# Altium schematic sheet GSM.SchDoc
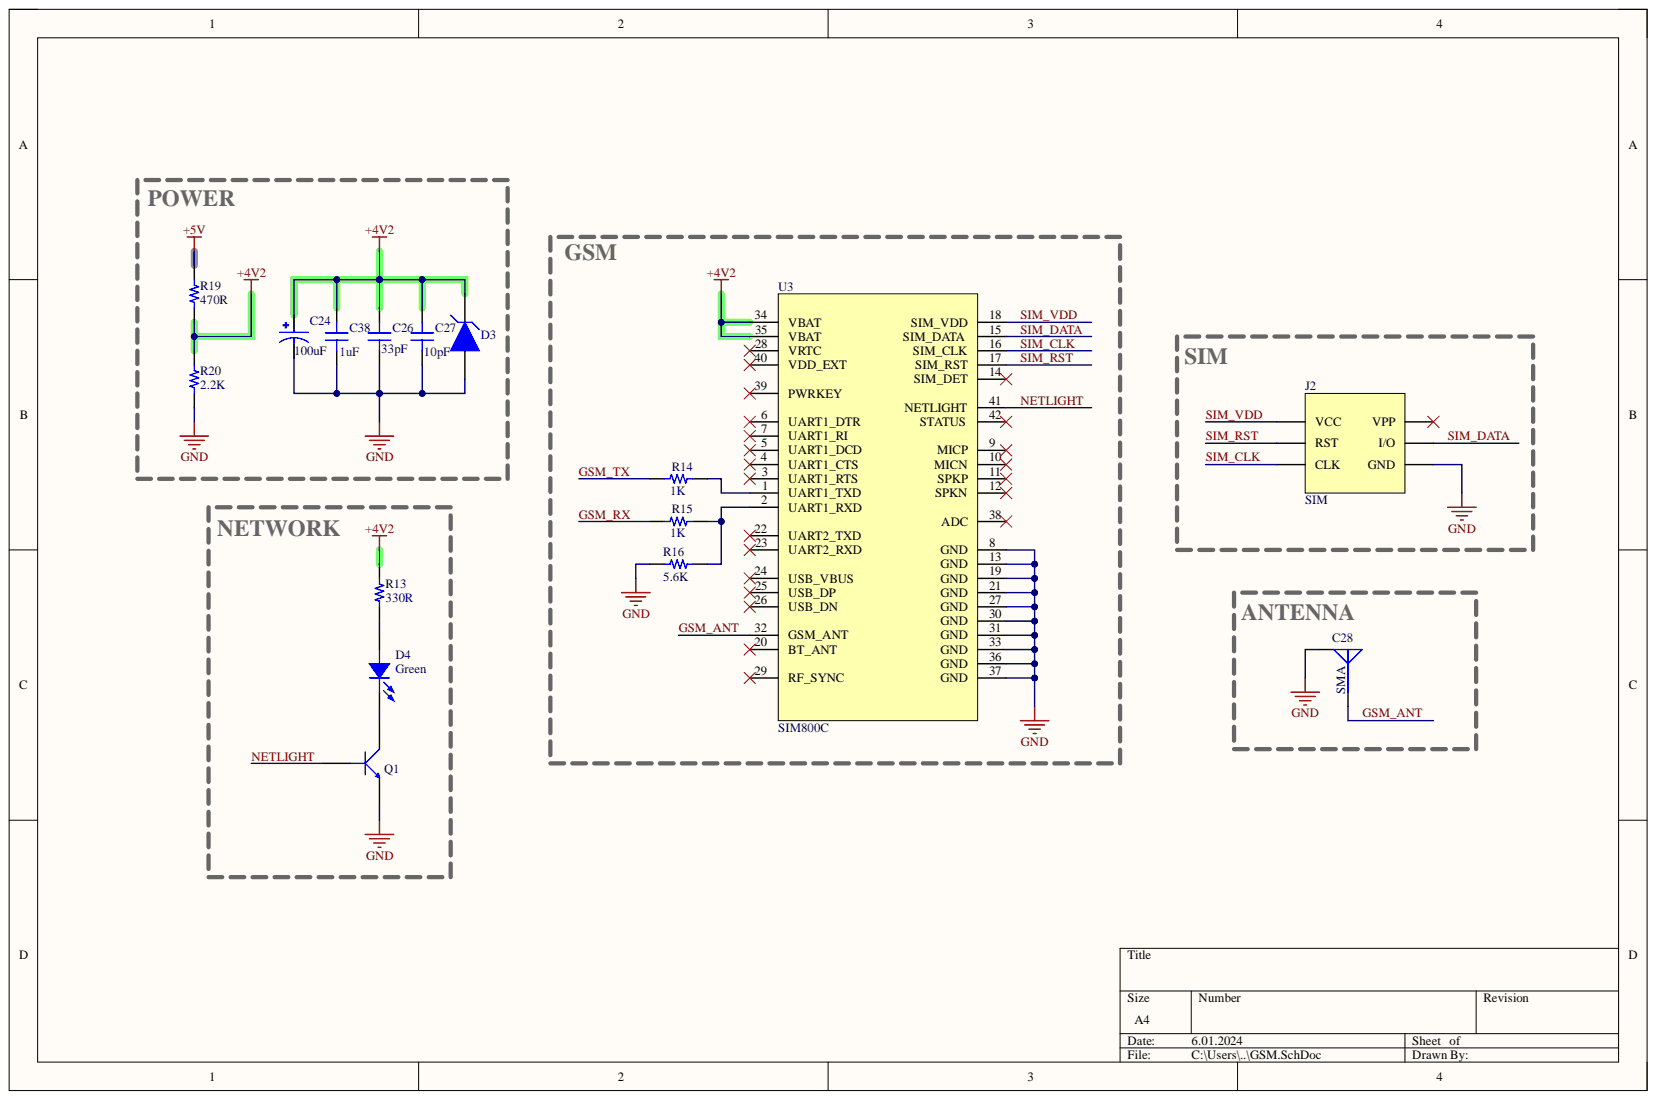
[diagram p0: GSM.SchDoc]

## Parts
C24  100uF  [TANTAL B-CASE]
C26  33pF  [CAP 0603_1608]
C27  10pF  [CAP 0603_1608]
C28  SMA  [132134-11 SMA ANTEN]
C38  1uF  [CAP 0603_1608]
D3  ZENER DIODE  [SOD123]  (value not drawn)
D4  value Green  [0805 Green LED]  (hidden comment: 0805 Green LED)
J2  SIM  [GCT_SIM7100-6-1-15-00-A_REVC]
Q1  MMBT3904-7-F  [SOT-23F]  (value not drawn)
R13  330R  [RES 0603_1608]
R14  1K  [RES 0603_1608]
R15  1K  [RES 0603_1608]
R16  5.6K  [RES 0603_1608]
R19  470R  [RES 0603_1608]
R20  2.2K  [RES 0603_1608]
U3  SIM800C  [LCC110P1570X1760X250-42N]

## Nets
+4V2: C24.+ C26.2 C27.2 C38.2 D3.C R13.2 R19.1 R20.2 U3.34(VBAT) U3.35(VBAT)
+5V: R19.2
GND: C24.- C26.1 C27.1 C28.G C38.1 D3.A J2.C5(GND) Q1.2 R16.1 R20.1 U3.8(GND) U3.13(GND) U3.19(GND) U3.21(GND) U3.27(GND) U3.30(GND) U3.31(GND) U3.33(GND) U3.36(GND) U3.37(GND)
GSM_ANT: C28.S U3.32(GSM_ANT)
GSM_RX: R15.1
GSM_TX: R14.1
NETLIGHT: Q1.1 U3.41(NETLIGHT)
SIM_CLK: J2.C3(CLK) U3.16(SIM_CLK)
SIM_DATA: J2.C7(I/O) U3.15(SIM_DATA)
SIM_RST: J2.C2(RST) U3.17(SIM_RST)
SIM_VDD: J2.C1(VCC) U3.18(SIM_VDD)
NetD4_1: D4.1 R13.1
NetD4_2: D4.2 Q1.3
NetR14_2: R14.2 U3.1(UART1_TXD)
NetR15_2: R15.2 R16.2 U3.2(UART1_RXD)

Not connected: J2 C6(VPP); U3 3(UART1_RTS) 4(UART1_CTS) 5(UART1_DCD) 6(UART1_DTR) 7(UART1_RI) 9(MICP) 10(MICN) 11(SPKP) 12(SPKN) 14(SIM_DET) 20(BT_ANT) 22(UART2_TXD) 23(UART2_RXD) 24(USB_VBUS) 25(USB_DP) 26(USB_DN) 28(VRTC) 29(RF_SYNC) 38(ADC) 39(PWRKEY) 40(VDD_EXT) 42(STATUS)

## Library data of the parts
C24 = 100uF: TANTAL; Component Kind: Standard; Library Name: hava_kalitesi_olcumu.SchLib
C26 = 33pF: CERAMİC 1206 SMD; Component Kind: Standard; Library Name: hava_kalitesi_olcumu.SchLib
C27 = 10pF: CERAMİC 1206 SMD; Component Kind: Standard; Library Name: hava_kalitesi_olcumu.SchLib
C28 = Anten SMA  2: SMA Anten Connector; Component Kind: Standard; ComponentLink1Description: Özdisan; ComponentLink1URL: https://www.ozdisan.com/konnektor-ve-baglanti-elemanlari/koaksiyel-konnektorler/sma-konnektorler/CONSMA002-G; Library Name: hava_kalitesi_olcumu.SchLib
C38 = 1uF: CERAMİC 1206 SMD; Component Kind: Standard; Library Name: hava_kalitesi_olcumu.SchLib
D3 = ZENER DIODE: SOD123; Manufacturer: ON Semiconductor; Manufacturer Part Number: 1SMB5919BT3G; Breakdown Voltage: 5.88V; Element Configuration: Single; ESD Protection: Yes; Forward Voltage: 1.5V; Material: Plastic; Max Power Dissipation: 3W; Max Reverse Current: 5uA; Power Dissipation: 550mW; Test Current: 66.9mA; Tolerance: 5%; Voltage Rating (DC): 5.6V; Zener Current: 267mA; Impedance: 2R; Case/Package: SMB; Category: Zener Diodes; Component Kind: Standard; ComponentLink2Description: https://datasheet.ciiva.com/1182/1smb5913bt3-d-1182657.pdf?src-supplier=Element14; ComponentLink2URL: https://datasheet.ciiva.com/1182/1smb5913bt3-d-1182657.pdf?src-supplier=Element14; ComponentLink3Description: https://datasheet.ciiva.com/1182/1smb5913bt3-d-1182658.pdf?src-supplier=Element14; ComponentLink3URL: https://datasheet.ciiva.com/1182/1smb5913bt3-d-1182658.pdf?src-supplier=Element14; ComponentLink4Description: https://datasheet.ciiva.com/895/1smb5913bt3-d-895203.pdf?src-supplier=Digi-Key; ComponentLink4URL: https://datasheet.ciiva.com/895/1smb5913bt3-d-895203.pdf?src-supplier=Digi-Key; ComponentLink5Description: https://datasheet.ciiva.com/895/1smb5913bt3-d-895204.pdf?src-supplier=Digi-Key; ComponentLink5URL: https://datasheet.ciiva.com/895/1smb5913bt3-d-895204.pdf?src-supplier=Digi-Key; ComponentLink6Description: https://datasheet.ciiva.com/895/1smb5913bt3-d-895205.pdf?src-supplier=Digi-Key; ComponentLink6URL: https://datasheet.ciiva.com/895/1smb5913bt3-d-895205.pdf?src-supplier=Digi-Key; ComponentLink7Description: https://datasheet.ciiva.com/15198/getdatasheetpartid-311040-15198695.pdf?src-supplier=Verical; ComponentLink7URL: https://datasheet.ciiva.com/15198/getdatasheetpartid-311040-15198695.pdf?src-supplier=Verical; ComponentLink8Description: https://datasheet.ciiva.com/16311/1smb5913bt3-d-16311847.pdf?src-supplier=Future+Electronics; ComponentLink8URL: https://datasheet.ciiva.com/16311/1smb5913bt3-d-16311847.pdf?src-supplier=Future+Electronics; ComponentLink9Description: https://datasheet.ciiva.com/16472/1smb5913bt3-d-16472779.pdf?src-supplier=Avnet+Asia; ComponentLink9URL: https://datasheet.ciiva.com/16472/1smb5913bt3-d-16472779.pdf?src-supplier=Avnet+Asia; Contact Plating: Tin,Matte; Halogen Free: Halogen Free; Height: 2.13mm; Lead Free: Lead Free; Length: 4.32mm; Max Operating Temperature: 150°C; Max Reverse Leakage Current: 5uA; Min Operating Temperature: -65°C; Number of Pins: 2; Package Quantity: 1; Packaging: Tape and Reel; Power Rating: 3W; Pricing 1: 1=0,45000, 10=0,31900, 100=0,16110, 500=0,14274, 1000=0,11109 (USD); Radiation Hardening: No; REACH SVHC: No SVHC; RoHS: Yes; Supplier 1: Digi-Key; Supplier Part Number 1: 1SMB5919BT3GOSCT-ND; Voltage Tolerance: 5%; Width: 3.56mm; Zener Voltage: 5.6V; Library Name: hava_kalitesi_olcumu.SchLib
D4 = 0805 Green LED: Manufacturer: AVAGO Technologies; Manufacturer Code: HSMG-C170; Current: 20mA; Voltage: 2.2V; Component Kind: Standard; Farnell Code: 5790852; Unit Price: 0,134 €; Library Name: hava_kalitesi_olcumu.SchLib
J2 = SIM7100-6-1-15-00-A: Memory Card Connectors Micro SIM Card Connector - Push-Push Type, 6 Pin, SMT, 1.50mm Profile; MF: Global Connector Technology; Availability: Unavailable; Component Kind: Standard; ComponentLink1Description: Özdisan; ComponentLink1URL: https://ozdisan.com/konnektor-ve-baglanti-elemanlari/hafiza-konnektorleri/pc-kart-soketleri/L-KLS1-SIM-064-62P-H1; MP: SIM7100-6-1-15-00-A; Package: None; Price: None; SnapEDA_Link: https://www.snapeda.com/parts/SIM7100-6-1-15-00-A/Global%20Connector%20Technology/view-part/449163/?ref=snap; Library Name: hava_kalitesi_olcumu.SchLib
Q1 = MMBT3904-7-F: Transistor General Purpose BJT NPN 40 Volt 0.2A Automotive 3-Pin SOT-23; Manufacturer: Diodes Zetex; Collector Base Voltage (VCBO): 60V; Collector Emitter Breakdown Voltage: 40V; Collector Emitter Saturation Voltage: 300mV; Continuous Collector Current: 200mA; Designed by: BERK; Element Configuration: Single; Emitter Base Voltage (VEBO): 6V; Frequency: 300MHz; hFE Min: 100; Max Power Dissipation: 300mW; Number of Elements: 1; Power Dissipation: 350mW; Case/Package: SOT-23; Category: BJTs; Collector Emitter Voltage (VCEO): 40V; Collector-emitter Voltage-Max: 300mV; Component Kind: Standard; ComponentLink1Description: https://datasheet.ciiva.com/11201/ds30036-11201778.pdf?src-supplier=Avnet; ComponentLink1URL: https://datasheet.ciiva.com/11201/ds30036-11201778.pdf?src-supplier=Avnet; ComponentLink2Description: https://datasheet.ciiva.com/15138/getdatasheetpartid-53265-15138780.pdf?src-supplier=Verical; ComponentLink2URL: https://datasheet.ciiva.com/15138/getdatasheetpartid-53265-15138780.pdf?src-supplier=Verical; ComponentLink3Description: https://datasheet.ciiva.com/6361/ds30036-6361254.pdf?src-supplier=Digi-Key; ComponentLink3URL: https://datasheet.ciiva.com/6361/ds30036-6361254.pdf?src-supplier=Digi-Key; ComponentLink4Description: https://datasheet.ciiva.com/22711/1915516-22711750.pdf?src-supplier=Element14; ComponentLink4URL: https://datasheet.ciiva.com/22711/1915516-22711750.pdf?src-supplier=Element14; ComponentLink5Description: https://datasheet.ciiva.com/11198/ds30036-11198999.pdf?src-supplier=Avnet; ComponentLink5URL: https://datasheet.ciiva.com/11198/ds30036-11198999.pdf?src-supplier=Avnet; ComponentLink6Description: https://datasheet.ciiva.com/6361/ds30036-6361256.pdf?src-supplier=Digi-Key; ComponentLink6URL: https://datasheet.ciiva.com/6361/ds30036-6361256.pdf?src-supplier=Digi-Key; Contact Plating: Tin,Matte; Gain Bandwidth Product: 300MHz; Height: 1mm; Lead Free: Lead Free; Length: 3.05mm; Manufacturer Part Number: MMBT3904-7-F; Max Breakdown Voltage: 40V; Max Collector Current: 200mA; Max Frequency: 300MHz; Max Operating Temperature: 150°C; Min Operating Temperature: -55°C; Mount: Surface Mount; Number of Pins: 3; Package Quantity: 3000; Packaging: Tape and Reel; Polarity: NPN; Pricing 1: 1=0,12000, 10=0,08600, 25=0,08280, 100=0,04660, 500=0,03664, 1000=0,02544 (USD); Radiation Hardening: No; REACH SVHC: No SVHC; RoHS: Yes; RoHS Compliant: Yes; Series: -; Stock 1: 2393281; Supplier 1: Digi-Key; Supplier Part Number 1: MMBT3904-FDIDKR-ND; Transition Frequency: 300MHz; Voltage Rating (DC): 40V; Weight: 0.000282oz; Width: 1.4mm; Library Name: hava_kalitesi_olcumu.SchLib
R13 = 330R: SMT RES 1206; Component Kind: Standard; Library Name: hava_kalitesi_olcumu.SchLib
R14 R15 = 1K: SMT RES 1206; Component Kind: Standard; Library Name: hava_kalitesi_olcumu.SchLib
R16 = 5.6K: SMT RES 1206; Component Kind: Standard; Library Name: hava_kalitesi_olcumu.SchLib
R19 = 470R: SMT RES 1206; Component Kind: Standard; Library Name: hava_kalitesi_olcumu.SchLib
R20 = 2.2K: SMT RES 1206; Component Kind: Standard; Library Name: hava_kalitesi_olcumu.SchLib
U3 = SIM800C: GSM/Bluetooth; Component Kind: Standard; ComponentLink1Description: Robolink; ComponentLink1URL: https://www.robolinkmarket.com/sim800c-gsm-gprs-modulu; MP: SIM800C; Package: None; Price: None; Library Name: hava_kalitesi_olcumu.SchLib
